# Altium schematic sheet MCU_STM.SchDoc
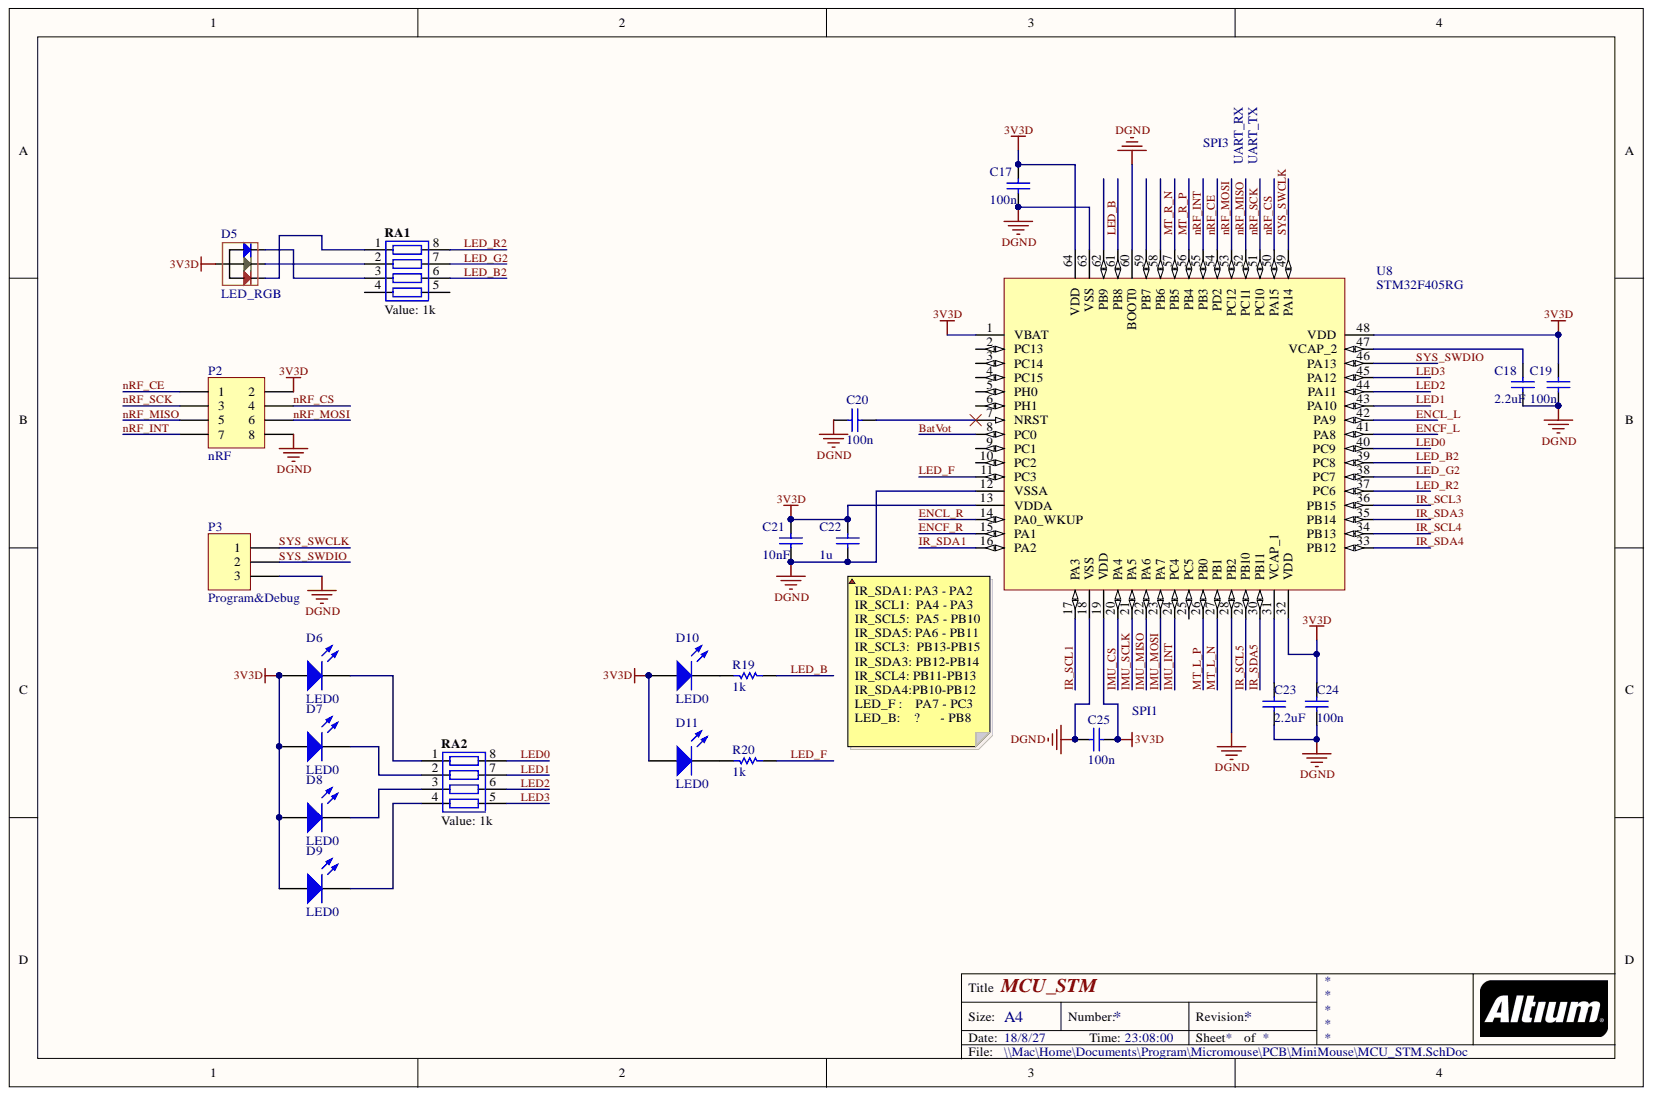
[diagram p0: MCU_STM.SchDoc]

## Parts
C17  100n  [CAPC1005[0402]]
C18  2.2uF  [CAPC1005[0402]]
C19  100n  [CAPC1005[0402]]
C20  100n  [CAPC1005[0402]]
C21  10nF  [CAPC1005[0402]]
C22  1u  [CAPC1005[0402]]
C23  2.2uF  [CAPC1005[0402]]
C24  100n  [CAPC1005[0402]]
C25  100n  [CAPC1005[0402]]
D5  LED_RGB  [LED_RGB]
D6  LED0  [CAPC1005N]
D7  LED0  [CAPC1005N]
D8  LED0  [CAPC1005N]
D9  LED0  [CAPC1005N]
D10  LED0  [CAPC1005N]
D11  LED0  [CAPC1005N]
P2  nRF  [1.27pitch-4X2pin]
P3  Program&Debug  [1.27pitch-3pin]
R19  1k  [RESC1005[0402]]
R20  1k  [RESC1005[0402]]
RA1  Value: 1k  value 1k  [RESCA1005[0402]8P]
RA2  Value: 1k  value 1k  [RESCA1005[0402]8P]
U8  STM32F405RG  [LQFP64-10X10mm]

## Nets
3V3D: C17.2 C19.2 C21.2 C22.2 C24.2 C25.1 D5.1 D6.1 D7.1 D8.1 D9.1 D10.1 D11.1 P2.2 U8.1(VBAT) U8.13(VDDA) U8.19(VDD) U8.32(VDD) U8.48(VDD) U8.64(VDD)
DGND: C17.1 C18.1 C19.1 C20.2 C21.1 C22.1 C23.1 C24.1 C25.2 P2.8 P3.3 U8.12(VSSA) U8.18(VSS) U8.28(PB2) U8.60(BOOT0) U8.63(VSS)
BatVot: U8.8(PC0)
ENCF_L: U8.41(PA8)
ENCF_R: U8.15(PA1)
ENCL_L: U8.42(PA9)
ENCL_R: U8.14(PA0_WKUP)
IMU_CS: U8.20(PA4)
IMU_INT: U8.24(PC4)
IMU_MISO: U8.22(PA6)
IMU_MOSI: U8.23(PA7)
IMU_SCLK: U8.21(PA5)
IR_SCL1: U8.17(PA3)
IR_SCL3: U8.36(PB15)
IR_SCL4: U8.34(PB13)
IR_SCL5: U8.29(PB10)
IR_SDA1: U8.16(PA2)
IR_SDA3: U8.35(PB14)
IR_SDA4: U8.33(PB12)
IR_SDA5: U8.30(PB11)
LED0: RA2.8 U8.40(PC9)
LED1: RA2.7 U8.43(PA10)
LED2: RA2.6 U8.44(PA11)
LED3: RA2.5 U8.45(PA12)
LED_B: R19.1 U8.61(PB8)
LED_B2: RA1.6 U8.39(PC8)
LED_F: R20.1 U8.11(PC3)
LED_G2: RA1.7 U8.38(PC7)
LED_R2: RA1.8 U8.37(PC6)
MT_L_N: U8.27(PB1)
MT_L_P: U8.26(PB0)
MT_R_N: U8.57(PB5)
MT_R_P: U8.56(PB4)
nRF_CE: P2.1 U8.54(PD2)
nRF_CS: P2.4 U8.50(PA15)
nRF_INT: P2.7 U8.55(PB3)
nRF_MISO: P2.5 U8.52(PC11)
nRF_MOSI: P2.6 U8.53(PC12)
nRF_SCK: P2.3 U8.51(PC10)
SYS_SWCLK: P3.1 U8.49(PA14)
SYS_SWDIO: P3.2 U8.46(PA13)
NetC18_2: C18.2 U8.47(VCAP_2)
NetC20_1: C20.1 U8.7(NRST)
NetC23_2: C23.2 U8.31(VCAP_1)
NetD5_2: D5.2 RA1.1
NetD5_3: D5.3 RA1.2
NetD5_4: D5.4 RA1.3
NetD6_2: D6.2 RA2.1
NetD7_2: D7.2 RA2.2
NetD8_2: D8.2 RA2.3
NetD9_2: D9.2 RA2.4
NetD10_2: D10.2 R19.2
NetD11_2: D11.2 R20.2

Not connected: RA1 4 5; U8 2(PC13) 3(PC14) 4(PC15) 5(PH0) 6(PH1) 9(PC1) 10(PC2) 25(PC5) 58(PB6) 59(PB7) 62(PB9)

## Library data of the parts
C17-C25 = Cap: Capacitor; Component Kind: Standard; LatestRevisionDate: 17-Jul-2002; LatestRevisionNote: Re-released for DXP Platform.; Library Name: Miscellaneous Devices.IntLib; PackageDescription: Radial Cap, Thru-Hole; 2 Leads; 0.3 in Pin Spacing; PackageReference: RAD-0.3; Published: 8-Jun-2000; Signal Integrity: Cap; Simulation: CAP; Publisher: Altium Limited
  C17 C19 C20 C24 C25: Value: 100n
  C18 C23: Value: 2.2uF
  C21: Value: 10nF
  C22: Value: 1u
D6-D11 = LED0: Typical INFRARED GaAs LED; Component Kind: Standard; LatestRevisionDate: 08-Jul-2005; LatestRevisionNote: Mech Layer 1 removed.; Library Name: Miscellaneous Devices.IntLib; PackageDescription: LED; 2 Leads; PackageReference: LED-0; Published: 8-Jun-2000; Simulation: LED0; Publisher: Altium Limited
P2 = Header 4X2: Header, 4-Pin, Dual row; Component Kind: Standard; LatestRevisionDate: 17-Jul-2002; LatestRevisionNote: Re-released for DXP Platform.; Library Name: Miscellaneous Integrated Library.IntLib; Price(RMB): 0.00; Signal Integrity: Connector; Publisher: Altium Limited
P3 = Header 3: Header, 3-Pin; Component Kind: Standard; LatestRevisionDate: 17-Jul-2002; LatestRevisionNote: Re-released for DXP Platform.; Library Name: Miscellaneous Integrated Library.IntLib; Price(RMB): 0.00; Signal Integrity: Connector; Publisher: Altium Limited
R19 R20 = Res1: Resistor; Component Kind: Standard; LatestRevisionDate: 17-Jul-2002; LatestRevisionNote: Re-released for DXP Platform.; Library Name: Miscellaneous Devices.IntLib; PackageDescription: Axial Device, Thru-Hole; 2 Leads; 0.3 in Pin Spacing; PackageReference: AXIAL-0.3; Published: 8-Jun-2000; Signal Integrity: Res; Simulation: RESISTOR; Value: 1k; Publisher: Altium Limited
RA1 RA2 = Res Ary 8p: Resistor Network, Isolated, 4 x 100R, 5%, SMD 0402 x 4; Component Kind: Standard; Library Name: Miscellaneous Integrated Library.IntLib; Price(RMB): 0.000; Tolerance: 5 %
D5 = LED_RGB: Component Kind: Standard; Library Name: LIQI.SchLib
U8 = STM32F405RG: Component Kind: Standard; Description: STM32F405RG; Library Name: Fairy.SchLib
